# Altium schematic sheet Analog.SchDoc
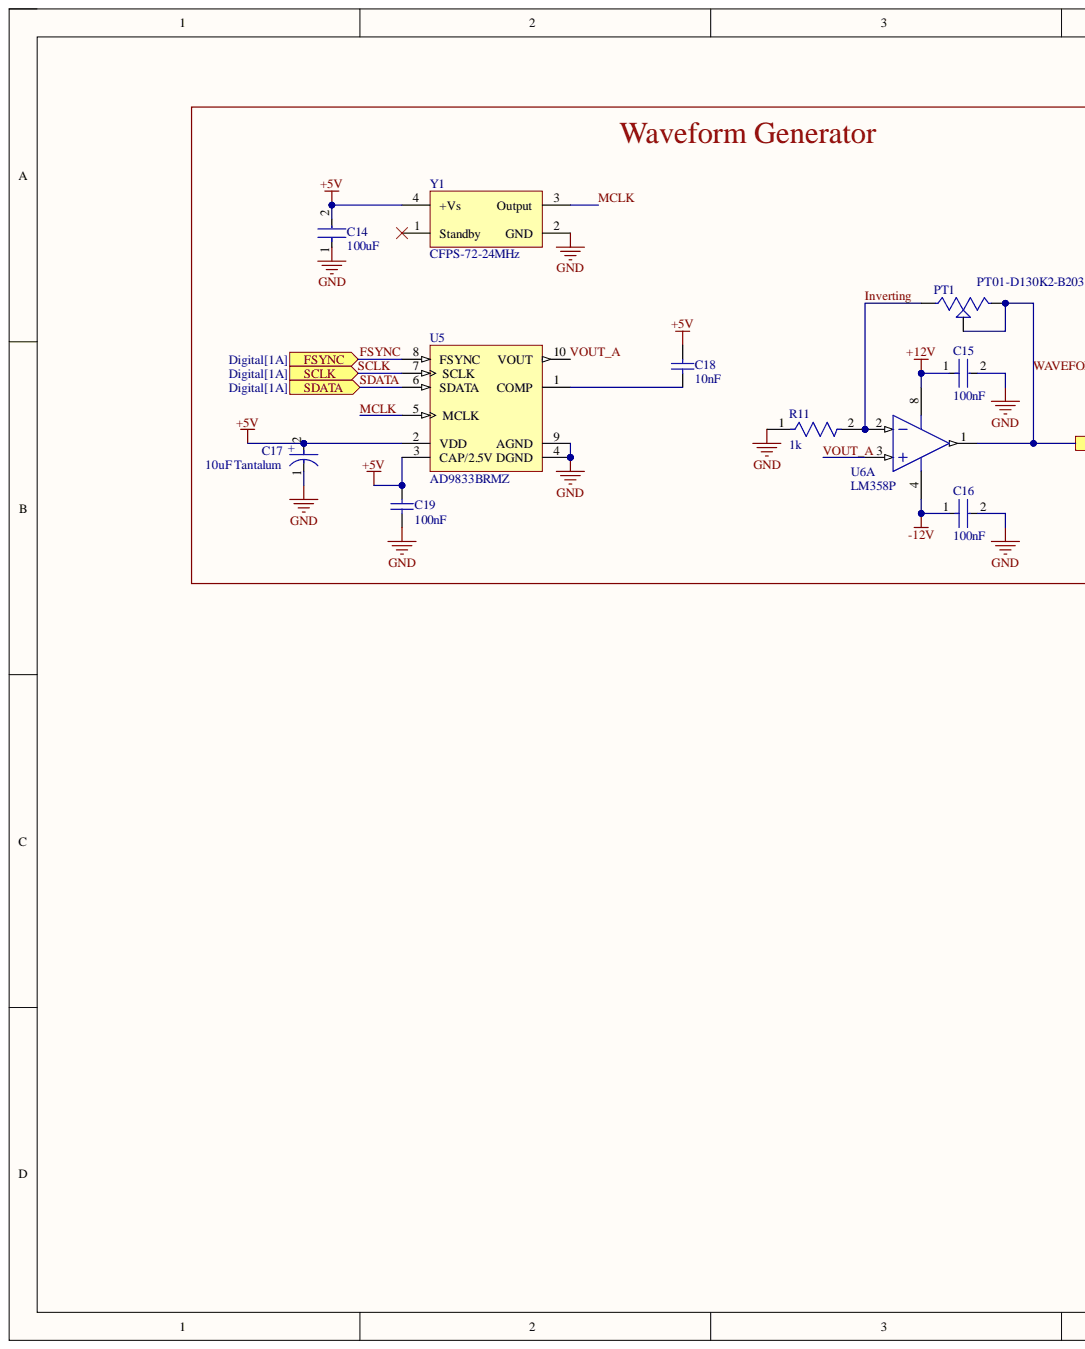
[diagram p0: Analog.SchDoc, left]
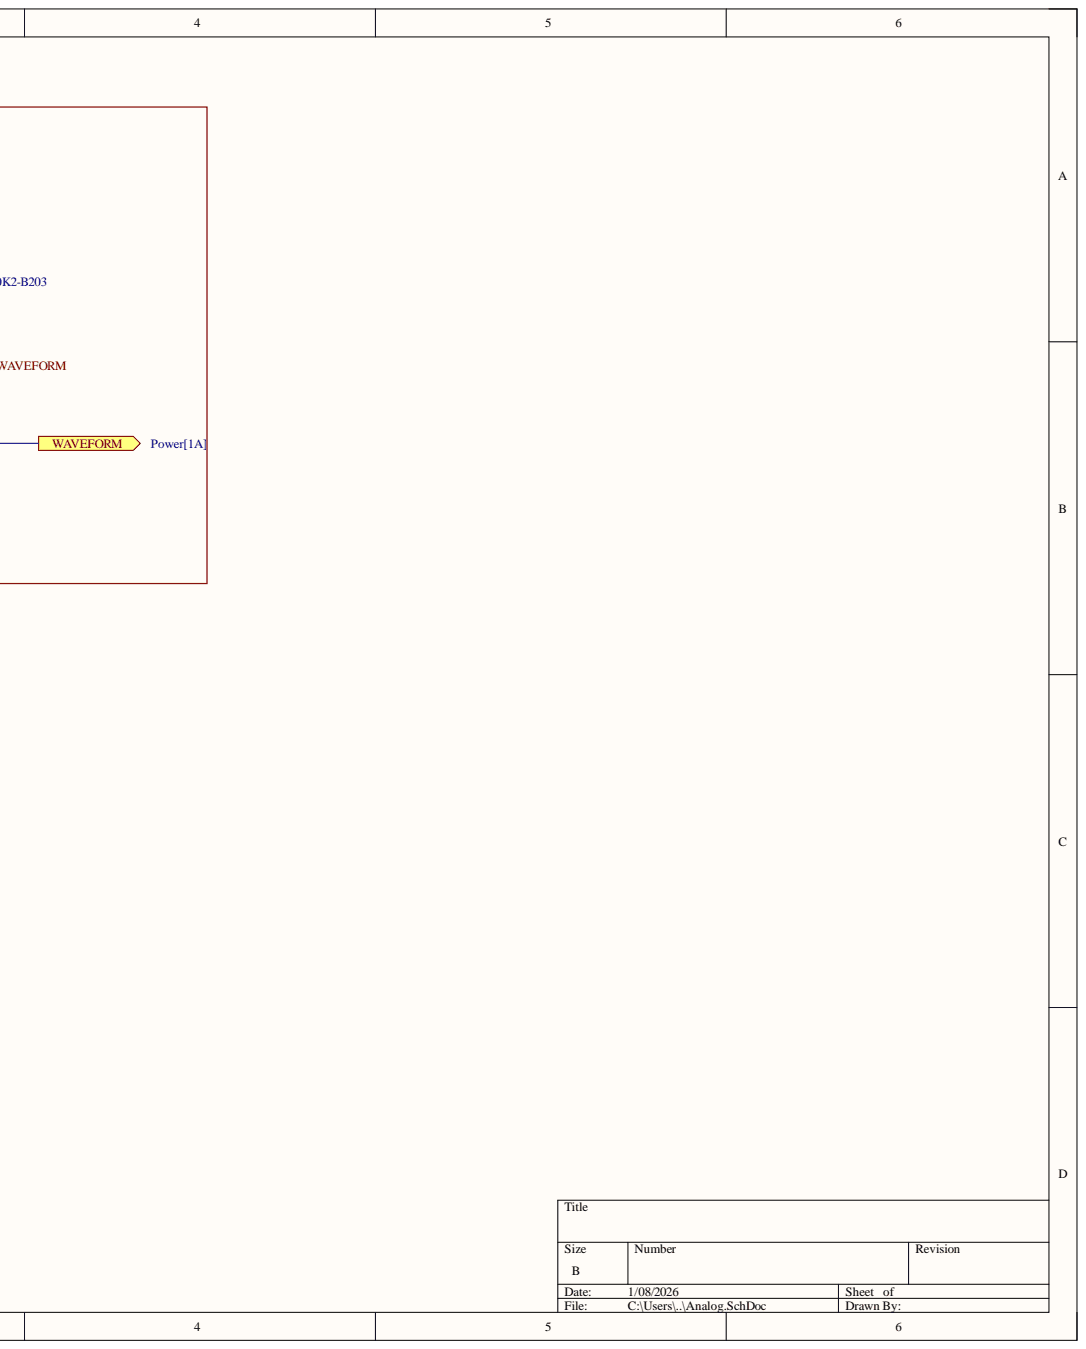
[diagram p1: Analog.SchDoc, right]

## Parts
C14  100uF  [FP-C1206C-EB-MFG]
C15  100nF  [FP-C1206C-EB-MFG]
C16  100nF  [FP-C1206C-EB-MFG]
C17  10uF Tantalum  Dielectric Material: Tantalum  [FP-293DB-MFG]
C18  10nF  [CAPC1206(3216)150_L]
C19  100nF  [CAPC1608X87X45LL20T05]
PT1  PT01-D130K2-B203  [TRIM_PT01-D130K2-B203]
R11  1k  [FP-MCU0805-IPC_B]
U5  AD9833BRMZ  [ADI-RM-10_M]
U6  LM358P  [P0008A]  (part A)
Y1  CFPS-72-24MHz  [WE-SPXO_CFPS-72]

## Ports
FSYNC, SCLK, SDATA, WAVEFORM

## Nets
+5V: C14.2 C17.2 C18.2 C19.2 U5.2(VDD) U5.3(CAP/2.5V) Y1.4(+Vs)
+12V: C15.1 U6.8
-12V: C16.1 U6.4
GND: C14.1 C15.2 C16.2 C17.1 C19.1 R11.1 U5.4(DGND) U5.9(AGND) Y1.2(GND)
FSYNC: U5.8(FSYNC) ; port FSYNC
Inverting: PT1.3 R11.2 U6.2
MCLK: U5.5(MCLK) Y1.3(Output)
SCLK: U5.7(SCLK) ; port SCLK
SDATA: U5.6(SDATA) ; port SDATA
VOUT_A: U5.10(VOUT) U6.3
WAVEFORM: PT1.1 PT1.2 U6.1 ; port WAVEFORM
NetC18_1: C18.1 U5.1(COMP)

Not connected: Y1 1(Standby)

## Library data of the parts
C14-C16 = CMP-03020-014955-1: C1206 0.10 μF C0G 10.00% 25 V X7R; Manufacturer: KEMET; Manufacturer Part Number: C1206C104K3GACTU; Automotive Grade: Grade 0; Capacitance: 0.10 μF; Material: Ceramic; Temperature Coefficient: 15%; Tolerance: 0.1; Value: 0.10 μF; Voltage Rating: 25 V; Automotive: Yes; Category: Cap; Component Kind: Standard; ComponentLink2Description: Datasheet; ComponentLink2URL: https://content.kemet.com/datasheets/KEM_C1007_X8R_ULTRA_150C_SMD.pdf; Device Class L1: Passive Components; Device Class L2: Capacitors; Device Class L3: Ceramic Capacitors; Height: 0.88mm; Ipc Land Pattern Name: CAPC320160X78; Lead Free: Yes; Library Name: Altium Content Vault; Mouser Description: Multilayer Ceramic Capacitors MLCC - SMD/SMT 25volt 0.1uF 10% C0G; Octopart: https://octopart.com/c1206c104k3gactu-kemet-12901041; Package: C1206C-EB; RoHS: TRUE; Temperature: -55°C to +150°C; Temperature Characteristic: C0G; Temperature Range High: +150°C; Temperature Range Low: -55°C
C17 = CMP-02414-000008-1: CAP TANT 10UF 10% 20V 1411; Dissipation Factor: 6%; ESR (Equivalent Series Resistance): 2.1R; Leakage Current: 2uA; Polarity: Polar; Q Factor: 6; Ripple Current: 200mA; Capacitance: 10uF; Case Code (Imperial): 1411; Case Code (Metric): 3528; Case/Package: 1411; Component Kind: Standard; Construction: Flat; Depth: 2.8mm; Features: General Purpose; Height: 1.9mm; Height - Seated (Max): 0.083inch; Lead Free: Lead Free; Length: 3.5mm; Library Name: Altium Content Vault; Max Operating Temperature: 125°C; Min Operating Temperature: -55°C; Mount: Surface Mount; Package Quantity: 1; Packaging: Tape and Reel; Radiation Hardening: No; RoHS Compliant: Yes; Series: TANTAMOUNT® 293D; Termination: SMD/SMT; Tolerance: 10%; Voltage: 20V; Voltage Rating: 20V; Voltage Rating (DC): 20V; Width: 0.11inch
C18 = CMP-1037-03452-1: CAP 10nF 10V ±5% 1206 (3216 Metric) Thickness 1.45mm SMD; Case-EIA: 1206; Case-Metric: 3216; Tolerance: Â±5%; Component Kind: Standard; Library Name: Altium Content Vault; Max Thickness: 1.45 mm; Rated Voltage: 10 V; Technology: SMT; Value: 10nF
C19 = CMP-2006-00001-1: Ceramic 100nF X7R 16V 5% 1206; Manufacturer: Kemet; Part Number: C0603X104J4RACTU; Component Kind: Standard; Library Name: Altium Content Vault
R11 = CMP-02407-004057-1: RES 1.05K OHM 0.5% 1/5W 0805; Component Kind: Standard; Library Name: Altium Content Vault
U5 = CMP-0293-00077-1: Low Power, 12.65 mW, 2.3 V to 5.5 V, Programmable Waveform Generator, 10-Bit, Serial, RM-10, 50 Tube, Pb-Free; Compliance Range (V): 650mV; FS Iout (mA nom): 3mA; I Supply total (max): 5.5mA; Master fclk: 25MHz; REFCLK Multiplier: No; Resolution (Bits): 10bit; Tuning Word Width (bits): 28bit; Code_JEDEC: MO-187-BA; Component Kind: Standard; ComponentLink1Description: Manufacturer URL; ComponentLink1URL: www.analog.com; ComponentLink2Description: Datasheet; ComponentLink2URL: http://www.analog.com/static/imported-files/data_sheets/AD9833.pdf; ComponentLink3Description: Package Specification; ComponentLink3URL: http://www.analog.com/static/imported-files/packages/PKG_PDF/MSOP%28RM%29/RM_10.pdf; DatasheetVersion: Rev. D; I/O Interface: Serial; Library Name: Altium Content Vault; PackageDescription: 10-Lead Mini Small Outline Package [MSOP]; PackageReference: RM-10; PackageVersion: 5/8/2005
U6 = CMP-2000-06549-1: Dual Operational Amplifier, 3 to 32 V, 0 to 70 degC, 8-Pin PDIP (P), RoHS, Tape and Reel; Manufacturer: Technik Industrial; Component Kind: Standard; Datasheet URL: http://www.ti.com/lit/ds/symlink/lm358.pdf; Datasheet Version: Rev. T, 04/2015; Library Name: Altium Content Vault; Manufacturer URL: http://www.technik.com.hk/; Mounting Technology: ThroughHole; Package Description: 8-Pin Double In-line Package, Body 9.59 x 6.35 mm, Pitch 2.54 mm; Package Reference: P8; Package URL: http://www.ti.com/lit/ml/mpdi001b/mpdi001b.pdf
Y1 = CMP-03913-116855899-1: 831019082 WE-SPXO Crystal Oscillator; Frequency: 24MHz; Component Kind: Standard; Height: 1.4mm; Length: 7mm; Library Name: Altium Content Vault; Max Operating Temperature: 70°C; Min Operating Temperature: 0°C; NexarPartId: 116855899; Width: 5mm
PT1 = PT01-D130K2-B203: Availability: Unavailable; Component Kind: Standard; Library Name: PT01-D130K2-B203.IntLib; MP: PT01-D130K2-B203; Package: None; Price: None
